# Altium schematic sheet PWR.SchDoc(PWR)
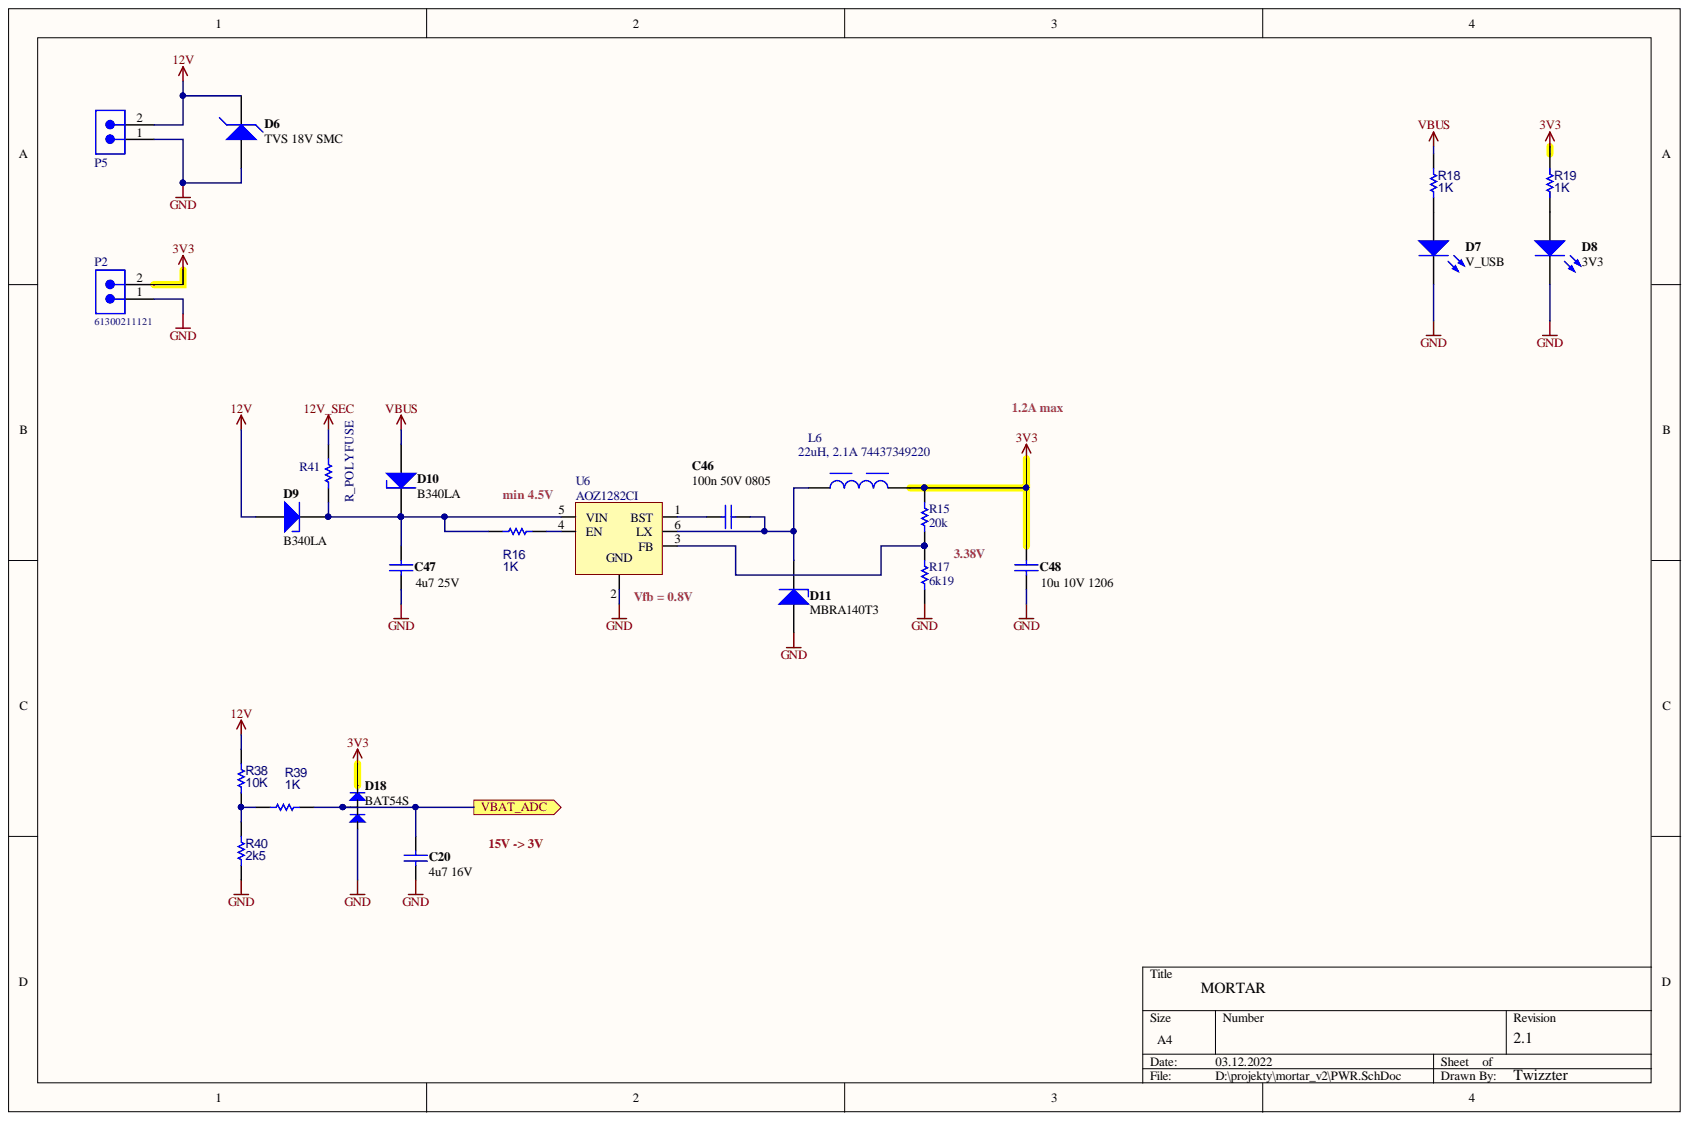
[diagram p0: PWR.SchDoc]

## Parts
C20  value 4u7 16V  [C_0603]  (hidden comment: C_4u7_16V_0603)
C46  100n 50V 0805  [C_0805]
C47  value 4u7 25V  [C_1206]  (hidden comment: 4u7 25V 1206)
C48  10u 10V 1206  [C_1206]
D6  TVS 18V SMC  [DO214AB_SMC]
D7  V_USB  [D_0805]
D8  3V3  [D_0805]
D9  B340LA  [D_SMA]
D10  B340LA  [D_SMA]
D11  MBRA140T3  [D_SMA]
D18  BAT54S  [SOT23]
L6  22uH, 2.1A 74437349220  Rated Current: 2.1A  [WE-LHMI_7050]
P2  61300211121  [61300211121]
P5  691325310002  [691325310002]  (value not drawn)
R15  20k  [R_0603]
R16  R_1K_0603  [R_0603]  (value not drawn)
R17  6k19  [R_0603]
R18  VALUE_TXT: 1K  [R_0603]  (hidden comment: R_1K_0603)
R19  VALUE_TXT: 1K  [R_0603]  (hidden comment: R_1K_0603)
R38  VALUE_TXT: 10K  [R_0603]  (hidden comment: R_10K_0603)
R39  VALUE_TXT: 1K  [R_0603]  (hidden comment: R_1K_0603)
R40  VALUE_TXT: 2k5  [R_0603]  (hidden comment: R_1K_0603)
R41  R_POLYFUSE  [R_1206]
U6  AOZ1282CI  [SOT23_6L]

## Ports
VBAT_ADC

## Nets
3V3: C48.2 D18.2 L6.2 P2.2 R15.2 R19.2
12V: D6.2 D9.1 P5.2 R38.2
12V_SEC: R41.2
GND: C20.1 C47.1 C48.1 D6.1 D7.2 D8.2 D11.1 D18.1 P2.1 P5.1 R17.1 R40.1 U6.2
VBUS: D10.1 R18.2
VBAT_ADC: C20.2 D18.3 R39.2 ; port VBAT_ADC
NetC46_1: C46.1 D11.2 L6.1 U6.6(LX)
NetC46_2: C46.2 U6.1(BST)
NetC47_2: C47.2 D9.2 D10.2 R16.1 R41.1 U6.5(VIN)
NetD7_1: D7.1 R18.1
NetD8_1: D8.1 R19.1
NetR15_1: R15.1 R17.2 U6.3(FB)
NetR16_2: R16.2 U6.4(EN)
NetR38_1: R38.1 R39.1 R40.2

## Library data of the parts
C20 = C_4u7_16V_0603: 4u7 16V 0603 X6S; mfg_pn: C1608X6S1C475K080AC; Library Name: SCH.SchLib; Component Kind: Standard
C46 = C_0805: 0805; Value: 100n 50V 0805; Library Name: SCH.SchLib; Component Kind: Standard
C47 C48 = C_1206: 1206; Library Name: SCH.SchLib; Component Kind: Standard
  C48: Value: 10u 10V 1206
D6 = D_TVS: TVS; Library Name: SCH.SchLib; Component Kind: Standard
D7 D8 = LED_0805: LED; mfg_pn: LL-S170VC-V1-1B; Library Name: SCH.SchLib; Component Kind: Standard
D9-D11 = D_SCHOTTKY_MBRA140T3: Diode; Library Name: SCH.SchLib; Component Kind: Standard
D18 = BAT54S: Diode; mfg_pn: BAT54SQ-7-F; link: https://pl.mouser.com/ProductDetail/Diodes-Incorporated/BAT54SQ-7-F?qs=sGAEpiMZZMtQ8nqTKtFS%2FCxNu2bLpS4AvuFrtQozqiHJPZeL9QFxYQ%3D%3D; Library Name: SCH.SchLib; Component Kind: Standard
L6 = 74437349220: WE-LHMI SMT Power Inductor, size 7050, 22uH, 2.1A; Manufacturer: Wurth Elektronik; Self Resonant Frequency: 8MHz; Saturation Current @30%: 8.5A; Saturation Current @10%: 4.15A; DC Resistance typ.: 140mOhm; DC Resistance max.: 170mOhm; Width: 6.6mm; Size: 7050; Packaging: Tape and Reel; Operating Temperature: -40 to +125degC; Mounting Technology: SMT; Manufacturer Part Number: 74437349220; Length: 6.6mm; Inductance: 22uH; Height: 4.8mm; ComponentLink2URL: https://www.we-online.com/catalog/datasheet/74437349220.pdf; ComponentLink2Description: Datasheet; ComponentLink1URL: http://www.we-online.de; ComponentLink1Description: Manufacturer; Component Kind: Standard
P2 = 61300211121: WR-PHD Pin Header, THT, pitch 2.54mm, Single Row, Vertical, 2p; Manufacturer: Wurth Elektronik; Working Voltage: 250VAC; Withstanding Voltage: 500VAC; Rated Current: 3A; Pin Number: 2; Contact Resistance: 20mOhm; Row Number: Single; Published Date: May 2020; Pitch: 2.54mm; Packaging: Bag; Orientation: Vertical; Operating Temperature: -40 to +105degC; Mounting Technology: THT; Manufacturer Part Number: 61300211121; Library Name: SCH.SchLib; Gender: Pin Header; ComponentLink2URL: https://www.we-online.com/catalog/datasheet/61300211121.pdf; ComponentLink2Description: Datasheet; ComponentLink1URL: http://www.we-online.de; ComponentLink1Description: Manufacturer; Component Kind: Standard
P5 = 691325310002: WR-TBL Series 3253 Horizontal PCB Header with Flanges, Through Hole, pitch 3.81mm, 2p; Manufacturer: Wurth Elektronik; Working Voltage: 300V; Rated Current: 10A; Pin Number: 2; Modularity: No; Pitch: 3.81mm; PCB/Cable/Panel: PCB; Packaging: Box; Orientation: Horizontal; Operating Temperature Min: -40°C; Operating Temperature Max: 105°C; Mount: Through Hole; Match Code: WR-TBL; Manufacturer Part Number: 691325310002; Library Name: For IntLib.DbLib; ComponentLink2URL: https://www.we-online.com/redexpert/spec/691325310002?ad; ComponentLink2Description: Datasheet; ComponentLink1URL: https://www.we-online.com/redexpert/article/691325310002?ad; ComponentLink1Description: Manufacturer Link; Component Kind: Standard; Category: Terminal Blocks; Application: Pluggable
R15 R17 = R_0603: Library Name: SCH.SchLib; Component Kind: Standard
R16 = R_1K_0603: SMD RES 1K-1%-1/10W; Manufacturer: YAGEO; VALUE_TXT: 1K; Package: 0603; Library Name: SCH.SchLib; Component Kind: Standard
R18 R19 R39 R40 = R_1K_0603: SMD RES 1K-1%-1/10W; Manufacturer: YAGEO; Package: 0603; Library Name: SCH.SchLib; Component Kind: Standard
R38 = R_10K_0603: SMD RES 10K-5%-1/10W; Manufacturer: YAGEO; Package: 0603; Library Name: SCH.SchLib; Component Kind: Standard
R41 = R_1206: Library Name: SCH.SchLib; Description: R_1206; Component Kind: Standard
U6 = AOZ1282CI: EZBuck™ 1.2A Simple Buck Regulator; Library Name: SCH.SchLib; Component Kind: Standard
